# Altium schematic sheet [8]-SPI_Memory.SchDoc
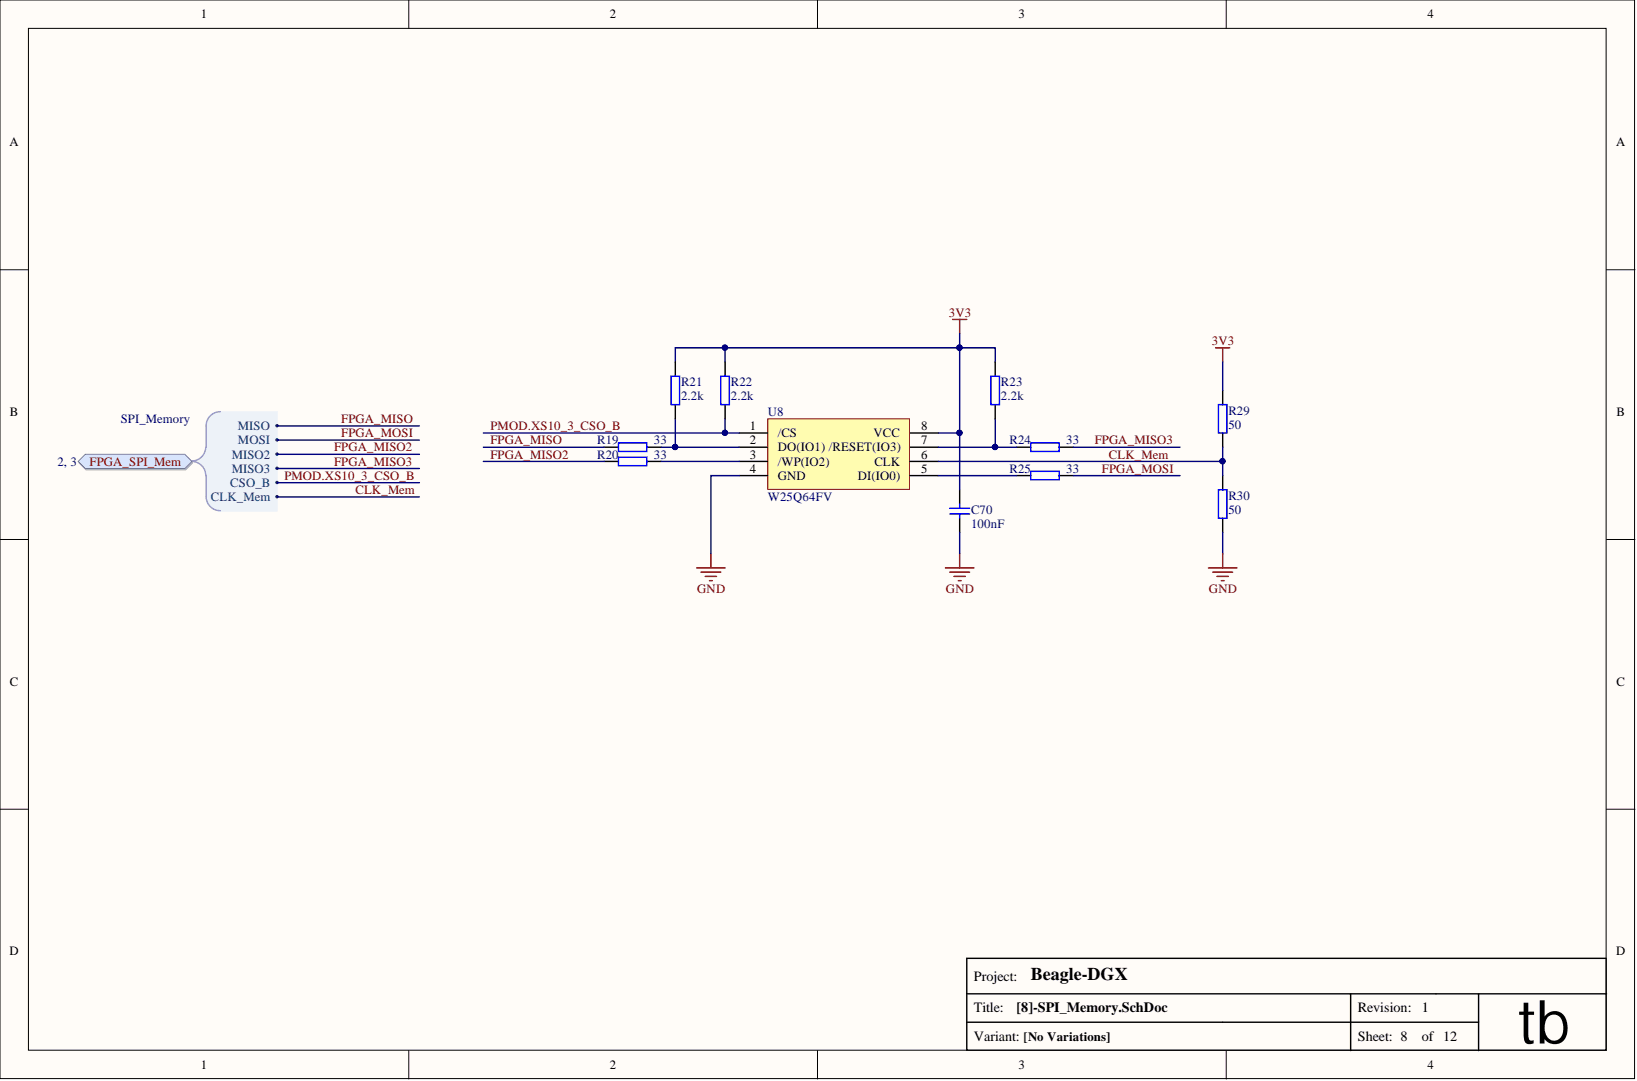
[diagram p0: [8]-SPI_Memory.SchDoc]

## Parts
C70  value 100nF  [C0603]  (hidden comment: CAP)
R19  value 33  [0603_RESISTOR]  (hidden comment: Resistor)
R20  value 33  [0603_RESISTOR]  (hidden comment: Resistor)
R21  value 2.2k  [0603_RESISTOR]  (hidden comment: Resistor)
R22  value 2.2k  [0603_RESISTOR]  (hidden comment: Resistor)
R23  value 2.2k  [0603_RESISTOR]  (hidden comment: Resistor)
R24  value 33  [0603_RESISTOR]  (hidden comment: Resistor)
R25  value 33  [0603_RESISTOR]  (hidden comment: Resistor)
R29  value 50  [0603_RESISTOR]  (hidden comment: Resistor)
R30  value 50  [0603_RESISTOR]  (hidden comment: Resistor)
U8  W25Q64FV  [SOIC8_208mil]

## Ports
FPGA_SPI_Mem, FPGA_SPI_Mem.CLK_Mem, FPGA_SPI_Mem.CSO_B, FPGA_SPI_Mem.MISO, FPGA_SPI_Mem.MISO2, FPGA_SPI_Mem.MISO3, FPGA_SPI_Mem.MOSI

## Nets
3V3: C70.2 R21.2 R22.2 R23.2 R29.2 U8.8(VCC)
GND: C70.1 R30.1 U8.4(GND)
CLK_Mem: R29.1 R30.2 U8.6(CLK) ; port FPGA_SPI_Mem
FPGA_MISO: R19.1 ; port FPGA_SPI_Mem
FPGA_MISO2: R20.1 ; port FPGA_SPI_Mem
FPGA_MISO3: R24.2 ; port FPGA_SPI_Mem
FPGA_MOSI: R25.2 ; port FPGA_SPI_Mem
PMOD.XS10_3_CSO_B: R22.1 U8.1(/CS) ; port FPGA_SPI_Mem
NetR19_2: R19.2 R21.1 U8.2(DO(IO1))
NetR20_2: R20.2 U8.3(/WP(IO2))
NetR23_1: R23.1 R24.1 U8.7(/RESET(IO3))
NetR25_1: R25.1 U8.5(DI(IO0))

## Library data of the parts
C70 = CAP: Manufacturer: Vishay / Vitramon; Manufacturer Part: VJ0603Y104JXJPW1BC; Type of Component: Ceramic Capacitor; Component Kind: Standard; Manufacturer Link: http://www.vishay.com/product?docid=28548; Package / Case: 0603; Supplier: mouser; Supplier Link: http://www.mouser.com/ProductDetail/Vishay/VJ0603Y104JXJPW1BC/?qs=%2fha2pyFaduidGoO0X7aK7Xgs%2fjxfhnLiK6SRw4Lz0%252bZK3PJ8DddTrQ%3d%3d; Supplier Part: 77-VJ0603Y104JXJPBC; Library Name: RPI-VITA.IntLib
R19 R20 R24 R25 = Resistor: Manufacturer: Vishay / Dale; Manufacturer Part: CRCW060333R0FKEA; Component Kind: Standard; Manufacturer Link: http://www.vishay.com/product?docid=20035; Package / Case: 0603; Supplier: mouser; Supplier Link: http://www2.mouser.com/ProductDetail/Vishay-Dale/CRCW060333R0FKEA/?qs=sGAEpiMZZMvdGkrng054t1X0qgDPqELUwAjRIFbU4%252bo%3d; Supplier Part: 71-CRCW0603-33-E3; Type of Component: Resistor; Library Name: RPI-VITA.IntLib
R21-R23 = Resistor: Manufacturer: Vishay / Dale; Manufacturer Part: CRCW06032K20JNEA; Component Kind: Standard; Manufacturer Link: http://www.vishay.com/product?docid=20035; Package / Case: 0603; Supplier: mouser; Supplier Link: http://www.mouser.com/ProductDetail/Vishay-Dale/CRCW06032K20JNEA/?qs=sGAEpiMZZMvdGkrng054txEw7b1YnvGuRJLBQI6feSk%3d; Supplier Part: 71-CRCW0603J-2.2K-E3; Type of Component: Resistor; Library Name: RPI-VITA.IntLib
R29 R30 = Resistor: Manufacturer: Vishay / Dale; Manufacturer Part: CRCW060350R0FKEA; Component Kind: Standard; Manufacturer Link: http://www.vishay.com/product?docid=20035; Package / Case: 0603; Supplier: mouser; Supplier Link: http://www2.mouser.com/ProductDetail/Vishay-Dale/CRCW060350R0FKEA/?qs=sGAEpiMZZMvdGkrng054txCzvDpDazy7AJnNXXoMwoE%3d; Supplier Part: 71-CRCW0603-50-E3; Type of Component: Resistor; Library Name: RPI-VITA.IntLib
U8 = W25Q128FV: 64M-bit Serial Flash Memory; Manufacturer: Winbond; Type of Component: SPI Memory; Component Kind: Standard; Manufacturer Link: https://www.winbond.com/hq/product/code-storage-flash-memory/serial-nor-flash/?__locale=en&partNo=W25Q64FV; Package / Case: SOIC-8; Supplier: HK-Ada; Supplier Part: W25Q64FV; Value: W25Q64FV; Library Name: RPI-VITA.IntLib
